# Altium schematic sheet 555_SCHEMATIC.SchDoc(555_SCHEMATIC)
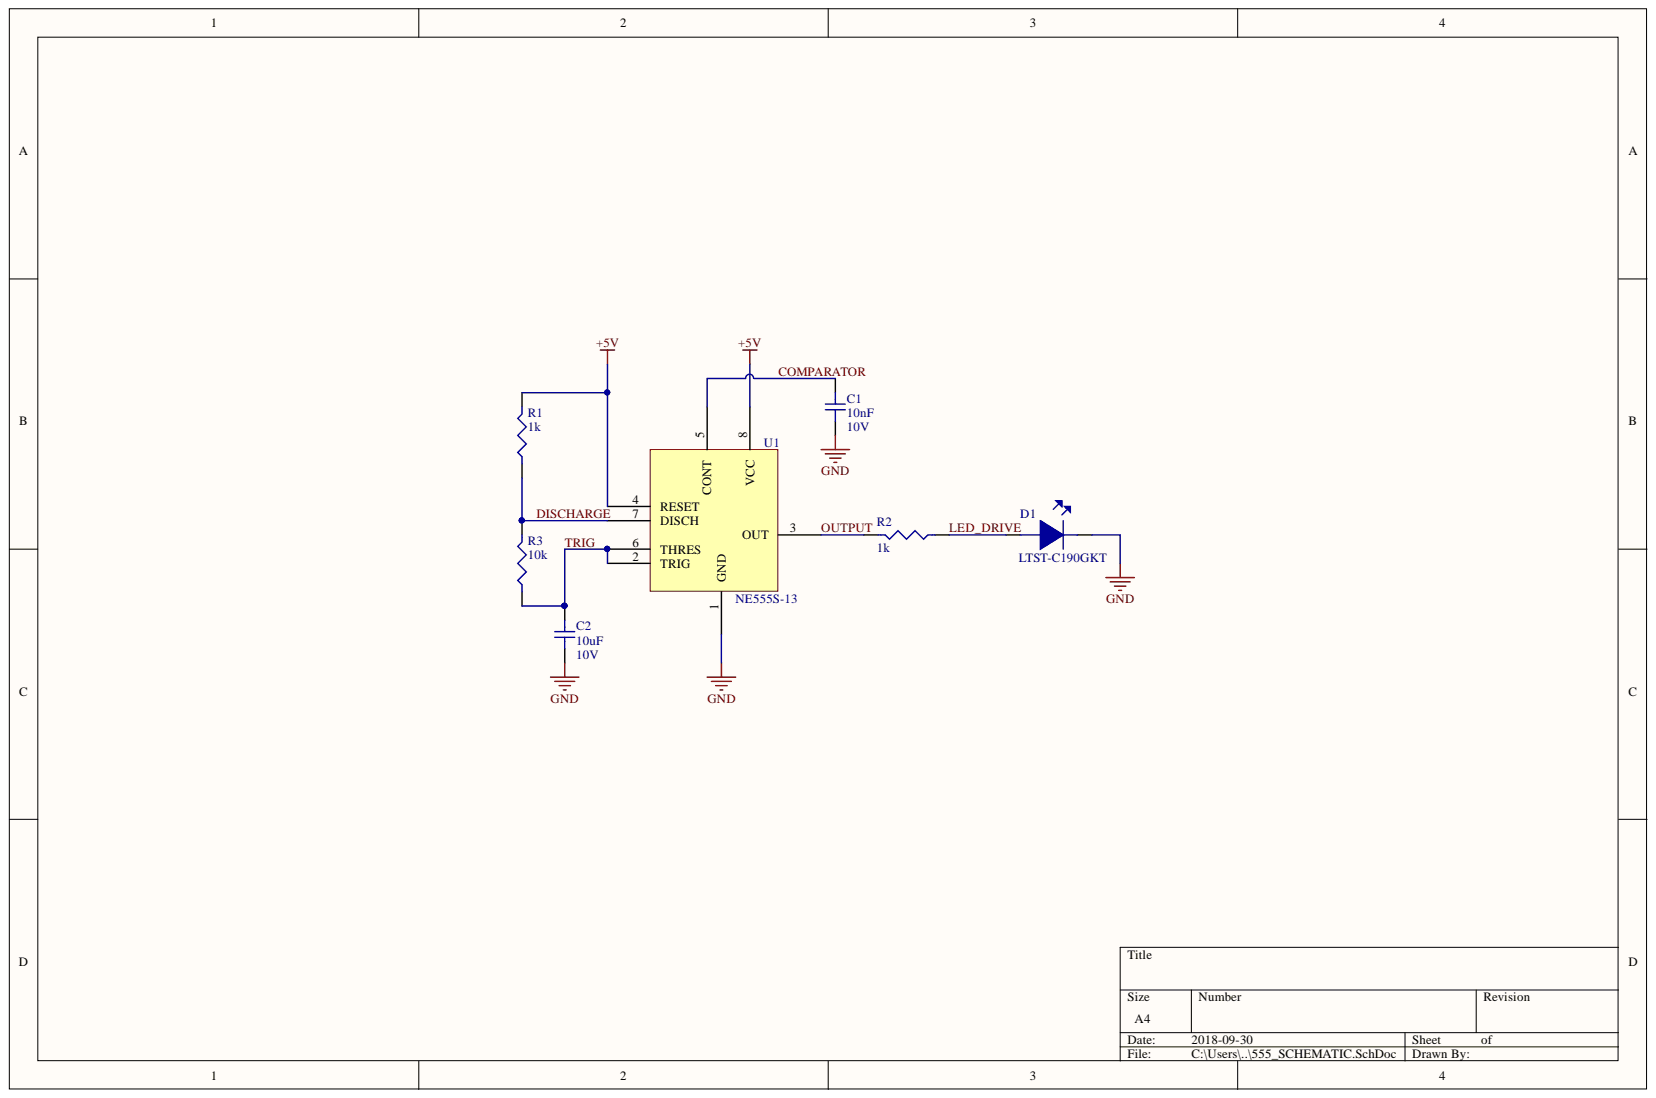
[diagram p0: 555_SCHEMATIC.SchDoc]

## Parts
C1  10nF  Voltage Rating (DC): 10V  [C0603]
C2  10uF  Voltage Rating (DC): 10V  [C0603]
D1  LTST-C190GKT  [LED_0603]
R1  1k  [R0603]
R2  1k  [R0603]
R3  10k  [R0603]
U1  NE555S-13  [SOIC-8]

## Nets
+5V: R1.2 U1.4(RESET) U1.8(VCC)
GND: C1.1 C2.1 D1.2 U1.1(GND)
COMPARATOR: C1.2 U1.5(CONT)
DISCHARGE: R1.1 R3.2 U1.7(DISCH)
LED_DRIVE: D1.1 R2.1
OUTPUT: R2.2 U1.3(OUT)
TRIG: C2.2 R3.1 U1.2(TRIG) U1.6(THRES)

## Library data of the parts
C1 = CC0603KRX7R6BB103: Cap Ceramic 0.01uF 10V X7R 10% SMD 0603 125C Paper T/R; Manufacturer: Yageo; Width: 0.031inch; Voltage Rating: 10V; Tolerance: 10%; Thickness: 0.035inch; Supplier Part Number 1: 603-CC603KRX7R6BB103; Supplier 1: Mouser; Stock 1: 1987; Radiation Hardening: No; Pricing 1: 1=0.1, 10=0.039, 50=0.039, 100=0.018, 1000=0.014, 10000=0.01 (USD); Packaging: Tape and Reel; Mount: Surface Mount; Min Operating Temperature: -55°C; Max Operating Temperature: 125°C; Manufacturer Part Number: CC0603KRX7R6BB103; Library Name: SCH_LIB.SchLib; Length: 0.063inch; Lead Free: Lead Free; Height: 0.8mm; Halogen Free: Halogen Free; Dielectric: X7R; Depth: 0.8mm; Composition: Ceramic; Component Kind: Standard; Case/Package: 0603; Capacitance: 10nF
C2 = GRM188R61A106KE69D: Cap Ceramic 10uF 10V X5R 10% SMD 0603 85C Paper T/R; Manufacturer: Murata; Width: 0.031inch; Voltage Rating: 10V; Voltage: 10V; Tolerance: 10%; Thickness: 0.037inch; Supplier Part Number 1: 81-GRM188R61A106KE9D; Supplier 1: Mouser; Stock 1: 0; REACH SVHC: No SVHC; Pricing 1: 1=0.19, 10=0.131, 50=0.131, 100=0.066, 1000=0.04, 10000=0.029 (USD); Packaging: Tape and Reel; Mount: Surface Mount; Min Operating Temperature: -55°C; Max Operating Temperature: 85°C; Manufacturer Part Number: GRM188R61A106KE69D; Library Name: SCH_LIB.SchLib; Length: 1.6mm; Lead Free: Lead Free; Height: 0.8mm; Dielectric: X5R; Depth: 0.8mm; Component Kind: Standard; Case Code (Metric): 1608; Case Code (Imperial): 0603; Case/Package: 0603; Capacitance: 10uF
D1 = LTST-C190GKT: LED GREEN CLEAR 0603 SMD; Manufacturer: Vishay Lite-On; Wavelength: 565nm; Voltage: 2V; Viewing Angle: 130°; Test Current: 10mA; Reverse Voltage: 5V; Power Rating: 100mW; Number of LEDs: 1; Luminous Intensity: 6mcd; Lens Style: Clear,Colorless,Diffused,Rectangular; Lens Colour: Clear,Colorless; Forward Voltage: 2.1V; Forward Current: 30mA; Dominant Wavelength: 569nm; Current: 1A; Width: 0.8mm; Supplier Part Number 1: 160-1183-6-ND; Supplier 1: Digi-Key; Stock 1: 1795364; Radiation Hardening: No; Pricing 1: 1=0.27, 10=0.196, 25=0.1448, 100=0.1034, 250=0.07032, 500=0.06204, 1000=0.0486 (USD); Power Dissipation: 100mW; Packaging: Tape and Reel; Package Quantity: 1; Number of Pins: 2; Number of Elements: 1; Mount: Surface Mount; Min Operating Temperature: -55°C; Max Operating Temperature: 85°C; Manufacturer Part Number: LTST-C190GKT; Library Name: SCH_LIB.SchLib; Lens Transparency: Clear; Length: 1.6mm; Lead Free: Lead Free; Illumination Colour: Green; Height: 0.8mm; Depth: 0.8mm; Component Kind: Standard; Colour: Green; Case Code (Metric): 1608; Case Code (Imperial): 0603; Case/Package: 0603
R1 R2 = ESR03EZPJ102: RES SMD 1K OHM 5% 1/4W 0603; Manufacturer: Rohm; Temperature Coefficient: 200ppm/°C; Resistance: 1kR; Max Power Dissipation: 250mW; Width: 0.031inch; Tolerance: 5%; Supplier Part Number 1: 2103938; Supplier 1: Future Electronics; Stock 1: 0; Pricing 1: 1=0.022, 250=0.0157, 750=0.0138, 1500=0.0122, 2500=0.011 (USD); Packaging: Tape and Reel; Package Quantity: 1; Number of Terminations: 2; Min Operating Temperature: -55°C; Max Operating Temperature: 155°C; Manufacturer Part Number: ESR03EZPJ102; Library Name: SCH_LIB.SchLib; Length: 0.063inch; Lead Free: Lead Free; Height: 0.022inch; Features: Automotive AEC-Q200; Composition: Thick Film; Component Kind: Standard; Case Code (Metric): 1608; Case Code (Imperial): 0603; Case/Package: 0603
R3 = RR0816P-103-D: RES SMD 10K OHM 0.5% 1/16W 0816; Manufacturer: Susumu; Voltage Rating (DC): 75V; Temperature Coefficient: 25ppm/°C; Resistance: 10kR; Power Rating: 63mW; Width: 0.8mm; Weight: 7.1E-05oz; Voltage Rating: 75V; Tolerance: 0.5%; Termination: SMD/SMT; Supplier Part Number 1: RR0816P-103-D; Supplier 1: Arrow; Stock 1: 7768; REACH SVHC: Unknown; Pricing 1: 1=0.09, 10=0.045, 25=0.0405, 100=0.0198, 250=0.0178, 500=0.016 (USD); Packaging: Tape and Reel; Package Quantity: 1; Number of Terminations: 2; Number of Pins: 2; Min Operating Temperature: -55°C; Max Power Dissipation: 63mW; Max Operating Temperature: 125°C; Manufacturer Part Number: RR0816P-103-D; Library Name: SCH_LIB.SchLib; Length: 1.6mm; Lead Free: Lead Free; Height: 0.4mm; Depth: 0.8mm; Composition: Thin Film; Component Kind: Standard; Case Code (Metric): 1608; Case Code (Imperial): 0603; Case/Package: 0603
U1 = NE555S-13: 555 Type, Timer/Oscillator (Single) IC 500kHz 8-SOIC (0.154", 3.90mm Width); Manufacturer: Diodes; Quiescent Current: 15mA; Operating Supply Current: 13mA; Number of Timers/Counters: 1; Min Supply Voltage: 4.5V; Max Supply Voltage: 16V; Low Level Output Current: 200mA; High Level Output Current: -200mA; Width: 3.95mm; Weight: 0.01787oz; Supplier Part Number 1: NE555S-13DIDKR-ND; Supplier 1: Digi-Key; Stock 1: 2624; REACH SVHC: No SVHC; Radiation Hardening: No; Pricing 1: 1=0.37, 10=0.303, 100=0.206, 500=0.15452, 1000=0.11588 (USD); Packaging: Tape and Reel; Package Quantity: 2500; Number of Pins: 8; Mount: Surface Mount; Min Operating Temperature: 0°C; Max Operating Temperature: 70°C; Manufacturer Part Number: NE555S-13; Library Name: SCH_LIB.SchLib; Length: 4.95mm; Lead Free: Lead Free; Height: 1.5mm; Frequency: 500kHz; Contact Plating: Tin,Matte; ComponentLink3URL: https://datasheet.ciiva.com/1232/ne555-sa555-na555-1232521.pdf; ComponentLink3Description: https://datasheet.ciiva.com/1232/ne555-sa555-na555-1232521.pdf; ComponentLink2URL: https://datasheet.ciiva.com/1232/ne555-sa555-na555-1232520.pdf; ComponentLink2Description: https://datasheet.ciiva.com/1232/ne555-sa555-na555-1232520.pdf; ComponentLink1URL: https://datasheet.ciiva.com/1232/ne555-sa555-na555-1232519.pdf; ComponentLink1Description: https://datasheet.ciiva.com/1232/ne555-sa555-na555-1232519.pdf; Component Kind: Standard; Case/Package: SO
